# Altium schematic sheet TPVSI_Inductor_Current_Sensing.SchDoc(TPVSI_Inductor_Current_Sensing)
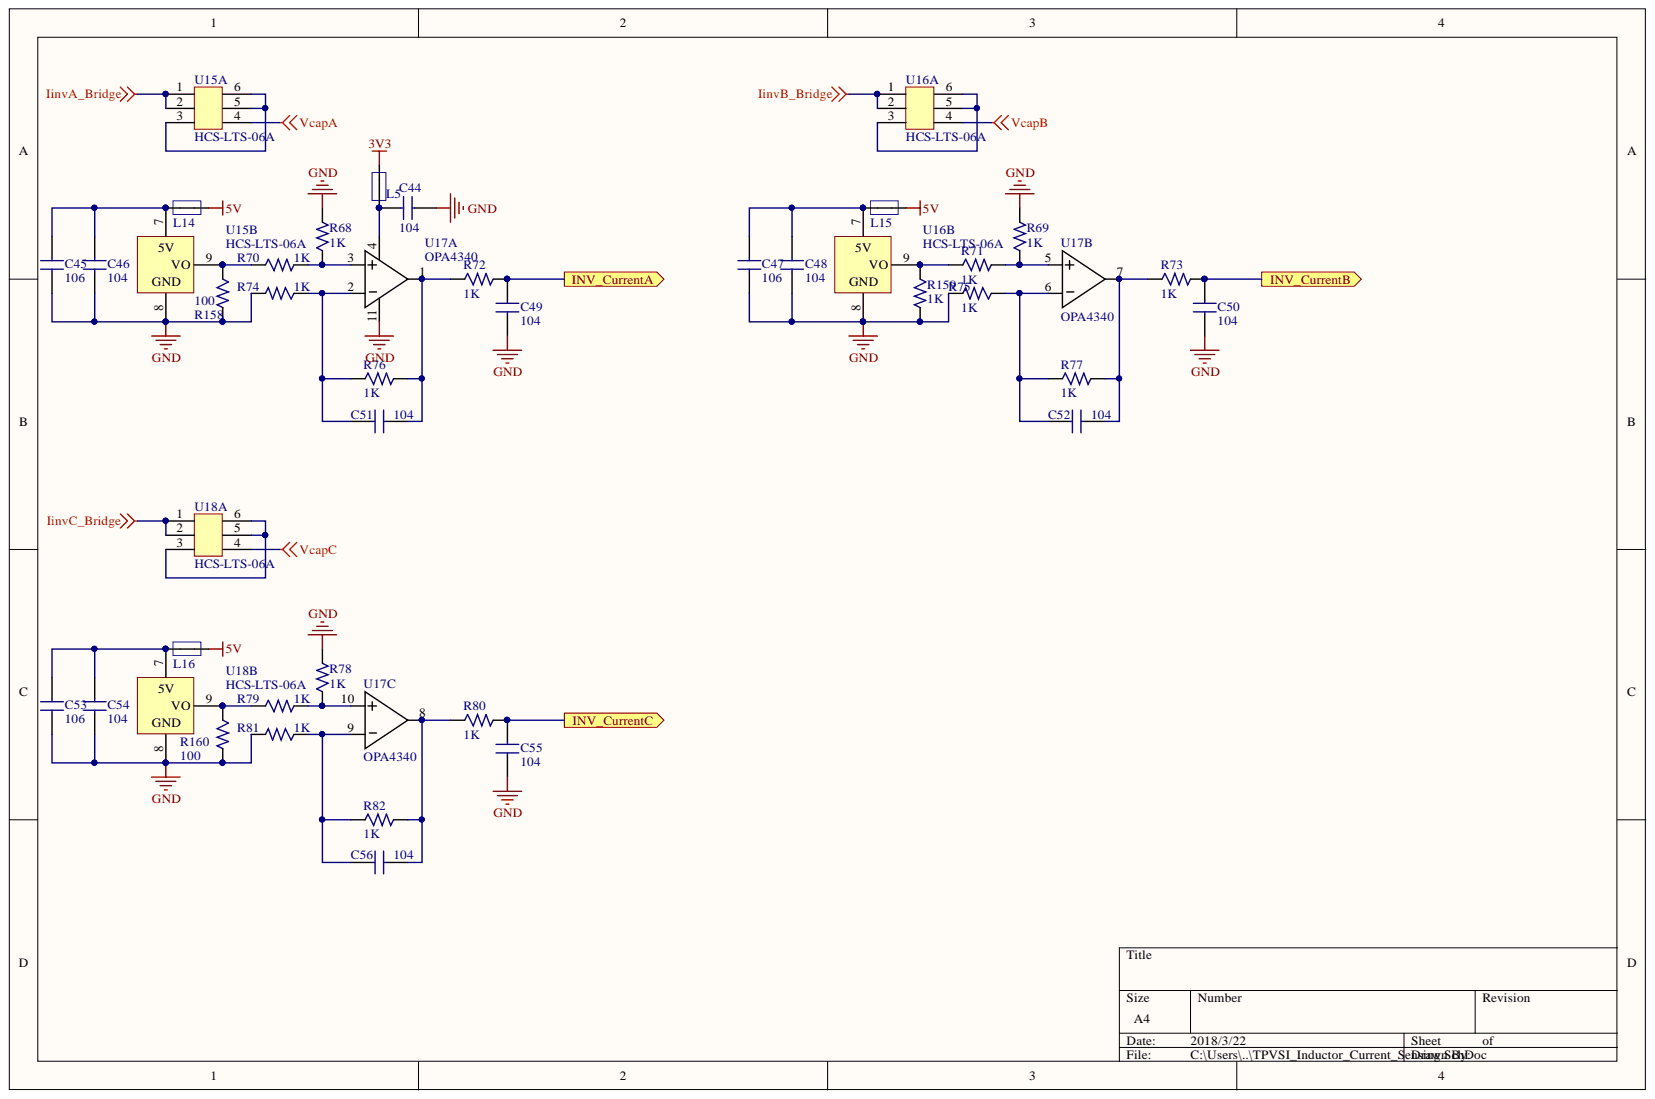
[diagram p0: TPVSI_Inductor_Current_Sensing.SchDoc]

## Parts
C44  104  [C-RAD-0603]
C45  106  [C-RAD-0603]
C46  104  [C-RAD-0603]
C47  106  [C-RAD-0603]
C48  104  [C-RAD-0603]
C49  104  [C-RAD-0603]
C50  104  [C-RAD-0603]
C51  104  [C-RAD-0603]
C52  104  [C-RAD-0603]
C53  106  [C-RAD-0603]
C54  104  [C-RAD-0603]
C55  104  [C-RAD-0603]
C56  104  [C-RAD-0603]
L5  Inductor_Small  [1206]  (value not drawn)
L14  Inductor_Small  [1206]  (value not drawn)
L15  Inductor_Small  [1206]  (value not drawn)
L16  Inductor_Small  [1206]  (value not drawn)
R68  1K  [0603_1]
R69  1K  [0603_1]
R70  1K  [0603_1]
R71  1K  [0603_1]
R72  1K  [0603_1]
R73  1K  [0603_1]
R74  1K  [0603_1]
R75  1K  [0603_1]
R76  1K  [0603_1]
R77  1K  [0603_1]
R78  1K  [0603_1]
R79  1K  [0603_1]
R80  1K  [0603_1]
R81  1K  [0603_1]
R82  1K  [0603_1]
R158  100  [0603_1]
R159  1K  [0603_1]
R160  100  [0603_1]
U15  HCS-LTS-06A  [HCS-LTS]  (parts A,B)
U16  HCS-LTS-06A  [HCS-LTS]  (parts A,B)
U17  OPA4340  [SOP-14N]  (parts A,B,C)
U18  HCS-LTS-06A  [HCS-LTS]  (parts A,B)

## Ports
INV_CurrentA, INV_CurrentB, INV_CurrentC

## Nets
3V3: L5.2
5V: L14.1 L15.1 L16.1
GND: C44.2 C45.1 C46.1 C47.1 C48.1 C49.1 C50.1 C53.1 C54.1 C55.1 R68.2 R69.2 R74.2 R75.2 R78.2 R81.2 R158.2 R159.2 R160.2 U15.8 U16.8 U17.11 U18.8
IinvA_Bridge: U15.1 U15.2
IinvB_Bridge: U16.1 U16.2
IinvC_Bridge: U18.1 U18.2
VcapA: U15.4
VcapB: U16.4
VcapC: U18.4
INV_CurrentA: C49.2 R72.2 ; port INV_CurrentA
INV_CurrentB: C50.2 R73.2 ; port INV_CurrentB
INV_CurrentC: C55.2 R80.2 ; port INV_CurrentC
NetC44_1: C44.1 L5.1 U17.4
NetC45_2: C45.2 C46.2 L14.2 U15.7(5V)
NetC47_2: C47.2 C48.2 L15.2 U16.7(5V)
NetC51_1: C51.1 R72.1 R76.2 U17.1
NetC51_2: C51.2 R74.1 R76.1 U17.2
NetC52_1: C52.1 R73.1 R77.2 U17.7
NetC52_2: C52.2 R75.1 R77.1 U17.6
NetC53_2: C53.2 C54.2 L16.2 U18.7(5V)
NetC56_1: C56.1 R80.1 R82.2 U17.8
NetC56_2: C56.2 R81.1 R82.1 U17.9
NetR68_1: R68.1 R70.2 U17.3
NetR69_1: R69.1 R71.2 U17.5
NetR70_1: R70.1 R158.1 U15.9(VO)
NetR71_1: R71.1 R159.1 U16.9(VO)
NetR78_1: R78.1 R79.2 U17.10
NetR79_1: R79.1 R160.1 U18.9(VO)
NetU15_3: U15.3 U15.5 U15.6
NetU16_3: U16.3 U16.5 U16.6
NetU18_3: U18.3 U18.5 U18.6

## Library data of the parts
C44-C56 = cap: Component Kind: Standard; Library Name: Chu_16.IntLib
R68-R82 R158-R160 = Res: Component Kind: Standard; Library Name: Chu_16.IntLib
L5 L14-L16 = Inductor_Small: Component Kind: Standard; Library Name: Chu_16.IntLib
U15 U16 U18 = HCS-LTS-06A: Component Kind: Standard; Library Name: Chu_16.IntLib
U17 = OPA4340: Component Kind: Standard; Library Name: Chu_16.IntLib
